# Altium schematic sheet MAX_2870.SchDoc(MAX_2870)
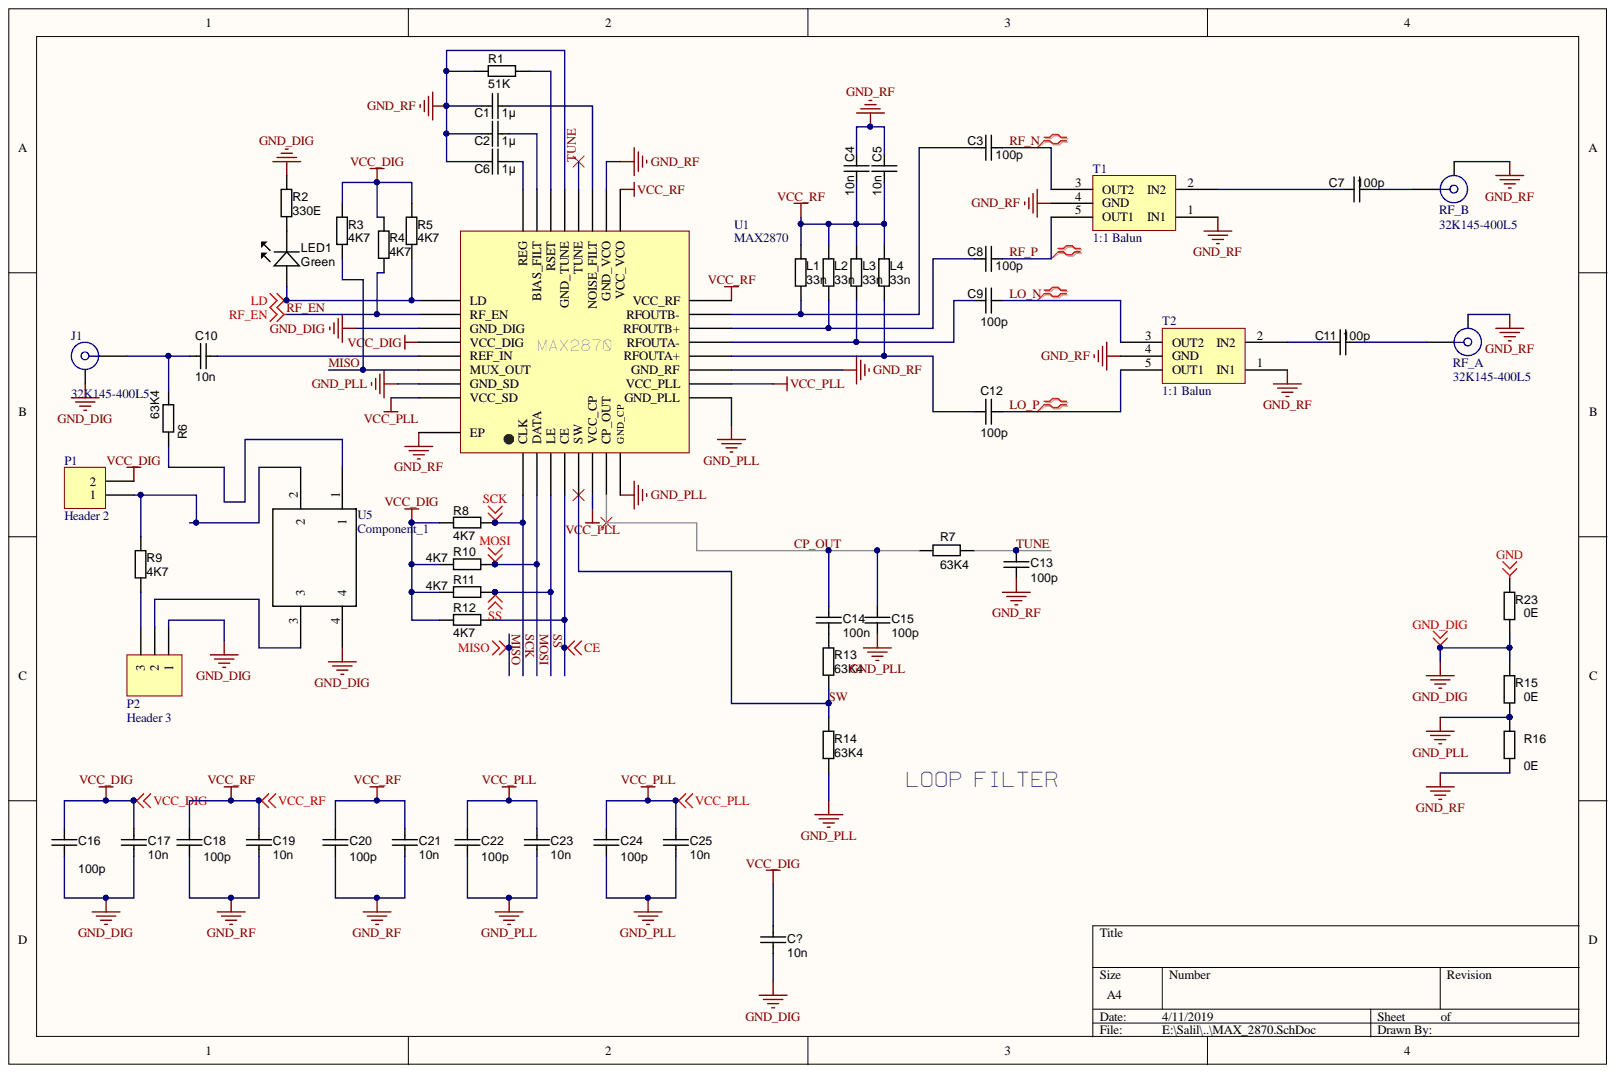
[diagram p0: MAX_2870.SchDoc]

## Parts
C1  value 1µ  [CAPC1608X90N]  (hidden comment: approved sub OK)
C2  value 1µ  [CAPC1608X90N]  (hidden comment: approved sub OK)
C3  100p  [CAPC1005X55N]
C4  value 10n  [CAPC1608X90N]  (hidden comment: approved sub OK)
C5  value 10n  [CAPC1608X90N]  (hidden comment: approved sub OK)
C6  value 1µ  [CAPC1608X90N]  (hidden comment: approved sub OK)
C7  100p  [CAPC1005X55N]
C8  100p  [CAPC1005X55N]
C9  100p  [CAPC1005X55N]
C10  value 10n  [CAPC1608X90N]  (hidden comment: approved sub OK)
C11  100p  [CAPC1005X55N]
C12  100p  [CAPC1005X55N]
C13  100p  [CAPC1608X90N]
C14  value 100n  [CAPC1608X90N]  (hidden comment: approved sub OK)
C15  100p  [CAPC1608X90N]
C16  100p  [CAPC1608X90N]
C17  value 10n  [CAPC1608X90N]  (hidden comment: approved sub OK)
C18  100p  [CAPC1608X90N]
C19  value 10n  [CAPC1608X90N]  (hidden comment: approved sub OK)
C20  100p  [CAPC1608X90N]
C21  value 10n  [CAPC1608X90N]  (hidden comment: approved sub OK)
C22  100p  [CAPC1608X90N]
C23  value 10n  [CAPC1608X90N]  (hidden comment: approved sub OK)
C24  100p  [CAPC1608X90N]
C25  value 10n  [CAPC1608X90N]  (hidden comment: approved sub OK)
C?  value 10n  [CAPC1608X90N]  (hidden comment: approved sub OK)
J1  32K145-400L5  [ROS-32K145-400L5_V]
L1  value 33n  [RESC1005X40N]  (hidden comment: Thick Film)
L2  value 33n  [RESC1005X40N]  (hidden comment: Thick Film)
L3  value 33n  [RESC1005X40N]  (hidden comment: Thick Film)
L4  value 33n  [RESC1005X40N]  (hidden comment: Thick Film)
LED1  Green  [LED-0603-GREEN]
P1  Header 2  [HDR1X2]
P2  Header 3  [HDR1X3]
R1  value 51K  [RESC2012X70N]  (hidden comment: Thick Film)
R2  value 330E  [RESC1608X55N]  (hidden comment: Thick Film)
R3  value 4K7  [RESC1005X40N]  (hidden comment: Thick Film)
R4  value 4K7  [RESC1005X40N]  (hidden comment: Thick Film)
R5  value 4K7  [RESC1005X40N]  (hidden comment: Thick Film)
R6  value 63K4  [RESC1608X55N]  (hidden comment: Thick Film)
R7  value 63K4  [RESC1608X55N]  (hidden comment: Thick Film)
R8  value 4K7  [RESC1005X40N]  (hidden comment: Thick Film)
R9  value 4K7  [RESC2012X70N]  (hidden comment: Thick Film)
R10  value 4K7  [RESC1005X40N]  (hidden comment: Thick Film)
R11  value 4K7  [RESC1005X40N]  (hidden comment: Thick Film)
R12  value 4K7  [RESC1005X40N]  (hidden comment: Thick Film)
R13  value 63K4  [RESC1608X55N]  (hidden comment: Thick Film)
R14  value 63K4  [RESC1608X55N]  (hidden comment: Thick Film)
R15  value 0E  [RESC1608X55N]  (hidden comment: Thick Film)
R16  value 0E  [RESC1608X55N]  (hidden comment: Thick Film)
R23  value 0E  [RESC1608X55N]  (hidden comment: Thick Film)
RF_A  32K145-400L5  [ROS-32K145-400L5_V]
RF_B  32K145-400L5  [ROS-32K145-400L5_V]
T1  1:1 Balun  [ETC-1-1-13R]
T2  1:1 Balun  [ETC-1-1-13R]
U1  MAX2870  [TQFN]
U5  Component_1  [5mm x 7mm TCXO]

## Nets
CE: R12.2 U1.4(CE)
GND: R23.1
GND_DIG: C16.2 C17.2 C?.2 J1.MP P2.1 R2.1 R15.1 R23.2 U1.27(GND_DIG) U5.4
GND_PLL: C15.1 C22.2 C23.2 C24.2 C25.2 R14.2 R15.2 R16.1 U1.8(GND_CP) U1.9(GND_PLL) U1.31(GND_SD)
GND_RF: C1.2 C2.1 C4.2 C5.2 C6.1 C13.2 C18.2 C19.2 C20.2 C21.2 R1.2 R16.2 RF_A.MP RF_B.MP T1.1(IN1) T1.4(GND) T2.1(IN1) T2.4(GND) U1.11(GND_RF) U1.18(GND_VCO) U1.21(GND_TUNE) U1.33(EP)
LD: LED1.A R5.1 U1.25(LD)
VCC_DIG: C16.1 C17.1 C?.1 P1.2 R3.2 R4.2 R5.2 R8.1 R10.1 R11.1 R12.1 U1.28(VCC_DIG)
VCC_PLL: C22.1 C23.1 C24.1 C25.1 U1.6(VCC_CP) U1.10(VCC_PLL) U1.32(VCC_SD)
VCC_RF: C4.1 C5.1 C18.1 C19.1 C20.1 C21.1 L1.1 L2.1 L3.1 L4.1 U1.16(VCC_RF) U1.17(VCC_VCO)
CP_OUT: C14.1 C15.2 R7.2 U1.7(CP_OUT)
LO_N: C9.2 T2.3(OUT2)
LO_P: C12.2 T2.5(OUT1)
MISO: R3.1 U1.30(MUX_OUT)
MOSI: R10.2 U1.2(DATA)
RF_EN: R4.1 U1.26(RF_EN)
RF_N: C3.2 T1.3(OUT2)
RF_P: C8.2 T1.5(OUT1)
SCK: R8.2 U1.1(CLK)
SS: R11.2 U1.3(LE)
SW: R13.2 R14.1 U1.5(SW)
TUNE: C13.1 R7.1 U1.20(TUNE)
NetC1_1: C1.1 U1.19(NOISE_FILT)
NetC2_2: C2.2 U1.23(BIAS_FILT)
NetC3_1: C3.1 L1.2 U1.15(RFOUTB-)
NetC6_2: C6.2 U1.24(REG)
NetC7_1: C7.1 T1.2(IN2)
NetC7_2: C7.2 RF_B.1
NetC8_1: C8.1 L2.2 U1.14(RFOUTB+)
NetC9_1: C9.1 L3.2 U1.13(RFOUTA-)
NetC10_1: C10.1 J1.1 R6.2
NetC10_2: C10.2 U1.29(REF_IN)
NetC11_1: C11.1 T2.2(IN2)
NetC11_2: C11.2 RF_A.1
NetC12_1: C12.1 L4.2 U1.12(RFOUTA+)
NetC14_2: C14.2 R13.1
NetLED1_K: LED1.K R2.2
NetP1_1: P1.1 R9.1 U5.2
NetP2_2: P2.2 U5.3
NetP2_3: P2.3 R9.2
NetR1_1: R1.1 U1.22(RSET)
NetR6_1: R6.1 U5.1

## Library data of the parts
C1 C2 C6 = CAPC_1u_0603_X7R_16V_10%: Capacitor SMD Cer 1uF 10% 16V X7R 0603; Manufacturer Part Number 1: C1608X7R1C105K; Manufacturer 1: TDK Corporation; SCDType: spec; Ratings: 16V; X7R; Material: ceramic; Tolerance: ±10%; Supplier Part Number 1: 445-1604-1-ND; Supplier 1: Digi-Key; Package / Case: 0603 (1608 metric); Library Name: PBL - Capacitors.SCHLIB; ComponentLink1URL: http://product.tdk.com/capacitor/mlcc/en/documents/mlcc_commercial_general_en.pdf; ComponentLink1Description: http://product.tdk.com/capacitor/mlcc/en/documents/mlcc_commercial_general_en.pdf; Component Kind: Standard
C3 C7-C9 C11 C12 = CAPC_100p_0402_NP0_50V_5%: Capacitor SMD Cer 100pF 5% 50V NP0 0402; Manufacturer Part Number 1: CC0402JRNPO9BN101; Manufacturer 1: Yageo; SCDType: spec; Ratings: 50V; NP0; Value: 100p; Tolerance: ±5%; Supplier Part Number 4: 399-3194-1-ND; Supplier Part Number 3: 445-1247-1-ND; Supplier Part Number 2: 1276-1025-1-ND; Supplier Part Number 1: 311-1024-1-ND; Supplier 4: Digi-Key; Supplier 3: Digi-Key; Supplier 2: Digi-Key; Supplier 1: Digi-Key; Size / Dimension: 0.039" L x 0.020" W (1.00mm x 0.50mm); RoHS 4: RoHS Compliant; RoHS 3: RoHS Compliant; RoHS 2: RoHS Compliant; RoHS 1: RoHS Compliant; Package / Case: 0402 (1005 Metric); Operating Temperature: -55°C ~ 125°C; Manufacturer Part Number 4: C0402C101J5GACTU; Manufacturer Part Number 3: C1005C0G1H101J050BA; Manufacturer Part Number 2: CL05C101JB5NNNC; Manufacturer 4: Kemet; Manufacturer 3: TDK Corporation; Manufacturer 2: Samsung Electro-Mechanics America, Inc; Library Name: PBL - Capacitors.SCHLIB; ComponentLink4URL: http://www.kemet.com/datasheets&C0402C101J5GACTU; ComponentLink4Description: http://www.kemet.com/datasheets&C0402C101J5GACTU; ComponentLink3URL: http://product.tdk.com/capacitor/mlcc/en/documents/mlcc_commercial_general_en.pdf; ComponentLink3Description: http://product.tdk.com/capacitor/mlcc/en/documents/mlcc_commercial_general_en.pdf; ComponentLink2URL: http://www.samsungsem.com/servlet/FileDownload?type=spec&file=CL05C101JB5NNNC.pdf; ComponentLink2Description: http://www.samsungsem.com/servlet/FileDownload?type=spec&file=CL05C101JB5NNNC.pdf; ComponentLink1URL: http://www.yageo.com/documents/recent/UPY-GP_NP0_16V-to-50V_9.pdf; ComponentLink1Description: http://www.yageo.com/documents/recent/UPY-GP_NP0_16V-to-50V_9.pdf; Component Kind: Standard
C4 C5 C10 C17 C19 C21 C23 C25 C? = CAPC_10n_0603_X7R_100V_10%: Capacitor SMD Cer 10nF 10% 100V X7R 0603; Manufacturer Part Number: GCM188R72A103KA37D; Manufacturer: Murata Electronics North America; SCDType: undef; Ratings: 100V; X7R; Material: ceramic; Tolerance: ±10%; Supplier Part Number 1: 490-4781-1-ND; Supplier 1: Digi-Key; Package / Case: 0603 (1608 metric); Library Name: PBL - Capacitors.SCHLIB; Component Kind: Standard
C13 C15 C16 C18 C20 C22 C24 = CAPC_100p_0603_NP0_50V_1%: Capacitor SMD Cer 100pF 1% 50V NP0 0603; Manufacturer Part Number 1: 06035A101FAT2A; Manufacturer 1: AVX Corporation; SCDType: source; Ratings: 50V; NP0; Value: 100p; Tolerance: ±1%; Thickness (Max): 0.035" (0.90mm); Supplier Part Number 3: 399-7819-1-ND; Supplier Part Number 2: 1276-2159-1-ND; Supplier Part Number 1: 478-6202-1-ND; Supplier 3: Digi-Key; Supplier 2: Digi-Key; Supplier 1: Digi-Key; Size / Dimension: 0.063" L x 0.032" W (1.60mm x 0.81mm); RoHS 3: RoHS Compliant; RoHS 2: RoHS Compliant; RoHS 1: RoHS Compliant; Package / Case: 0603 (1608 Metric); Operating Temperature: -55°C ~ 125°C; Manufacturer Part Number 3: C0603C101F5GACTU; Manufacturer Part Number 2: CL10C101FB8NNNC; Manufacturer 3: Kemet; Manufacturer 2: Samsung Electro-Mechanics America, Inc; Library Name: PBL - Capacitors.SCHLIB; ComponentLink3URL: http://www.kemet.com/datasheets&C0603C101F5GACTU; ComponentLink3Description: http://www.kemet.com/datasheets&C0603C101F5GACTU; ComponentLink2URL: http://www.samsungsem.com/servlet/FileDownload?type=spec&file=CL10C101FB8NNNC.pdf; ComponentLink2Description: http://www.samsungsem.com/servlet/FileDownload?type=spec&file=CL10C101FB8NNNC.pdf; ComponentLink1URL: http://www.avx.com/docs/Catalogs/ccog.pdf; ComponentLink1Description: http://www.avx.com/docs/Catalogs/ccog.pdf; Component Kind: Standard
C14 = CAPC_100n_0603_X7R_50V_10%: Capacitor SMD Cer 100nF 10% 50V X7R 0603; Manufacturer Part Number 1: 06035C104KAT2A; Manufacturer 1: AVX Corporation; SCDType: spec; Ratings: 50V; X7R; Material: ceramic; Tolerance: ±10%; Supplier Part Number 2: 445-1314-1-ND; Supplier Part Number 1: 478-5052-1-ND; Supplier 2: Digi-Key; Supplier 1: Digi-Key; Package / Case: 0603 (1608 Metric); Manufacturer Part Number 2: C1608X7R1H104K; Manufacturer 2: TDK Corporation; Library Name: PBL - Capacitors.SCHLIB; ComponentLink2URL: http://product.tdk.com/capacitor/mlcc/en/documents/mlcc_commercial_general_en.pdf; ComponentLink2Description: http://product.tdk.com/capacitor/mlcc/en/documents/mlcc_commercial_general_en.pdf; ComponentLink1URL: http://www.avx.com/docs/Catalogs/cx7r.pdf; ComponentLink1Description: http://www.avx.com/docs/Catalogs/cx7r.pdf; Component Kind: Standard
J1 RF_A RF_B = 32K145-400L5: SMA Right Angle Jack, Edge Mount, Up to 18 GHz, -55 to 155 degC; Design Note 1: Footprint in library is a generic example - only application specific footprint delivers High Performance; Desgin Note 2: For more information about DRC setting, please look at following webpage, http://techdocs.altium.com/display/SCRT/Design+Rule+for+Edge-Mount+Connectors; PartNumber: 32K145-400L5; PackageVersion: b00; PackageReference: 32K145-400L5; PackageDescription: SMA Right Angle Jack, Edge Mount, 14.25 x 9.5 x 4.46 mm; Mounting Technology: Surface Mount; DatasheetVersion: b00; ComponentLink5URL: http://www.rosenberger.com/en/contact/sales/; ComponentLink5Description: Webshop / RFQ; ComponentLink4URL: http://rosenberger.de/ok/images/documents/spez/32.pdf; ComponentLink4Description: Series Overview / Design Note; ComponentLink3URL: http://rosenberger.de/ok/images/documents/db/32K145-400L5.pdf; ComponentLink3Description: Package Specification; ComponentLink2URL: http://rosenberger.de/ok/images/documents/db/32K145-400L5.pdf; ComponentLink2Description: Datasheet; ComponentLink1URL: http://www.rosenberger.de; ComponentLink1Description: Manufacturer URL; Component Kind: Standard; Library Name: Rosenberger SMA.IntLib
L1-L4 = RES_100K_0402_5%: Resistor SMD chip 100k Ohm 0.063W 5% 0402; Manufacturer Part Number 1: CRCW0402100KJNED; Manufacturer 1: Vishay Dale; SCDType: undef; Ratings: 1/16 W; Tolerance: ±5%; Supplier Part Number 2: 311-100KJRCT-ND; Supplier Part Number 1: 541-100KJCT-ND; Supplier 2: Digi-Key; Supplier 1: Digi-Key; Package / Case: 0402 (1005 Metric); Manufacturer Part Number 2: RC0402JR-07100KL; Manufacturer 2: Yageo; Library Name: PBL - Resistors.SCHLIB; ComponentLink2URL: http://www.yageo.com/documents/recent/PYu-RC0402_51_RoHS_L_6.pdf; ComponentLink2Description: http://www.yageo.com/documents/recent/PYu-RC0402_51_RoHS_L_6.pdf; ComponentLink1URL: http://www.vishay.com/doc?20035; ComponentLink1Description: http://www.vishay.com/doc?20035; Component Kind: Standard
LED1 = LED_SMD_Green_0603_570nm: LED 0603 SMD Green 570nm 50mcd 20mA; Manufacturer Part Number 1: APT1608CGCK; Manufacturer 1: Kingbright Company LLC; Wavelength - Peak: 574nm; Voltage - Forward (Vf) Typ: 2.1V; Voltage - Forward (Vf) @ 10mA: 2.0V; Viewing Angle: 120°; SCDType: source; Ratings: 20mcd min; 50 mcd typ; 570 nm; Material: AlGaInP; Lens Type: Clear; Lens Style/Size: Rectangle with Flat Top, 1.1mm x 0.8mm; Wavelength - Dominant: 570nm; Supplier Part Number 1: 754-1116-1-ND; Supplier 1: Digi-Key; RoHS 1: RoHS Compliant; Package / Case: 0603 (1608 metric); Library Name: PBL - Light Sources.SCHLIB; Current - Test: 20mA; ComponentLink1URL: http://www.us.kingbright.com/images/catalog/SPEC/APT1608CGCK.pdf; ComponentLink1Description: http://www.us.kingbright.com/images/catalog/SPEC/APT1608CGCK.pdf; Component Kind: Standard; Color: Green
P1 = Header 2: Header, 2-Pin; Signal Integrity: Connector; Library Name: Miscellaneous Connectors.IntLib; LatestRevisionNote: Re-released for DXP Platform.; LatestRevisionDate: 17-Jul-2002; Component Kind: Standard; Publisher: Altium Limited
P2 = Header 3: Header, 3-Pin; Signal Integrity: Connector; Library Name: Miscellaneous Connectors.IntLib; LatestRevisionNote: Re-released for DXP Platform.; LatestRevisionDate: 17-Jul-2002; Component Kind: Standard; Publisher: Altium Limited
R1 = RES_51K_0805_1%: Resistor SMD chip 51k Ohm 0.125W 1% 0805; Manufacturer Part Number 1: RC0805FR-0751KL; Manufacturer 1: Yageo; SCDType: spec; Ratings: 1/8W; Tolerance: ±1%; Supplier Part Number 1: 311-51.0KCRCT-ND; Supplier 1: Digi-Key; Package / Case: 0805 (2012 Metric); Library Name: PBL - Resistors.SCHLIB; Component Kind: Standard
R2 = RES_3K3_0603_5%: Resistor SMD chip 3.3k Ohm 0.1W 5% 0603; Manufacturer Part Number 1: RC0603JR-073K3L; Manufacturer 1: Yageo; SCDType: undef; Ratings: 1/10 W; Tolerance: ±5%; Supplier Part Number 1: 311-3.3KGRTR-ND; Supplier 1: Digi-Key; Package / Case: 0603 (1608 metric); Material: thick film; Library Name: PBL - Resistors.SCHLIB; Component Kind: Standard
R3-R5 R8 R10-R12 = RES_1M_0402_5%: Resistor SMD chip 1M Ohm 0.063W 5% 0402; Manufacturer Part Number 1: CRCW04021M00JNED; Manufacturer 1: Vishay Dale; SCDType: spec; Ratings: 1/16 W; Power Rating: 0.063W, 1/16W; Tolerance: ±5%; Supplier Part Number 2: 311-1.0MJRCT-ND; Supplier Part Number 1: 541-1.0MJCT-ND; Supplier 2: Digi-Key; Supplier 1: Digi-Key; Package / Case: 0402 (1005 Metric); Material: Thick Film; Manufacturer Part Number 2: RC0402JR-071ML; Manufacturer 2: Yageo; Library Name: PBL - Resistors.SCHLIB; ComponentLink2URL: http://www.yageo.com/documents/recent/PYu-RC0402_51_RoHS_L_6.pdf; ComponentLink2Description: http://www.yageo.com/documents/recent/PYu-RC0402_51_RoHS_L_6.pdf; ComponentLink1URL: http://www.vishay.com/doc?20035; ComponentLink1Description: http://www.vishay.com/doc?20035; Component Kind: Standard
R6 R7 R13 R14 = RES_63K4_0603_1%: Resistor SMD chip 63.4K Ohm 0.1W 1% 0603; Manufacturer Part Number 1: RC0603FR-0763K4L; Manufacturer 1: Yageo; SCDType: spec; Ratings: 1/10W; Tolerance: ±1%; Supplier Part Number 1: 311-63.4KHRCT-ND; Supplier 1: Digi-Key; Package / Case: 0603 (1608 Metric); Library Name: PBL - Resistors.SCHLIB; ComponentLink1URL: http://www.yageo.com/documents/recent/PYu-RC0603_51_RoHS_L_4.pdf; ComponentLink1Description: http://www.yageo.com/documents/recent/PYu-RC0603_51_RoHS_L_4.pdf; Component Kind: Standard
R9 = RES_4K7_0805_1%: Resistor SMD chip 4.7k Ohm 0.125W 1% 0805; Manufacturer Part Number 1: CRCW08054K70FKEA; Manufacturer 1: Vishay/Dale; SCDType: spec; Ratings: 1/8W; Tolerance: ±1%; Supplier Part Number 1: 541-4.70KCCT-ND; Supplier 1: Digi-Key; Package / Case: 0805 (2012 metric); Library Name: PBL - Resistors.SCHLIB; Component Kind: Standard
R15 R16 R23 = RES_1K_0603_1%: Resistor SMD chip 1k Ohm 0.1W 1% 0603; Manufacturer Part Number 1: RC0603FR-071KL; Manufacturer 1: Yageo; SCDType: spec; Ratings: 1/10W; Supplier Part Number 1: 311-1.00KHRCT-ND; Supplier 1: Digi-Key; Package / Case: 0603 (1608 Metric); Library Name: PBL - Resistors.SCHLIB; Component Kind: Standard
T1 T2 = ETC-1-1-13R: ETC-1-1-13R 1:1 Balun; Library Name: ETC1-1-13R balun.SchLib; ComponentLink1URL: https://cdn.macom.com/datasheets/ETC1-1-13.pdf; ComponentLink1Description: Datasheet; Component Kind: Standard
U1 = MAX2870: MAX2870 PLL with VCO; Library Name: MAX2870.SchLib; Component Kind: Standard
U5 = Component_1: Library Name: TCX).SchLib; Component Kind: Standard
